# Altium schematic sheet 04_VGA.SchDoc(04_VGA)
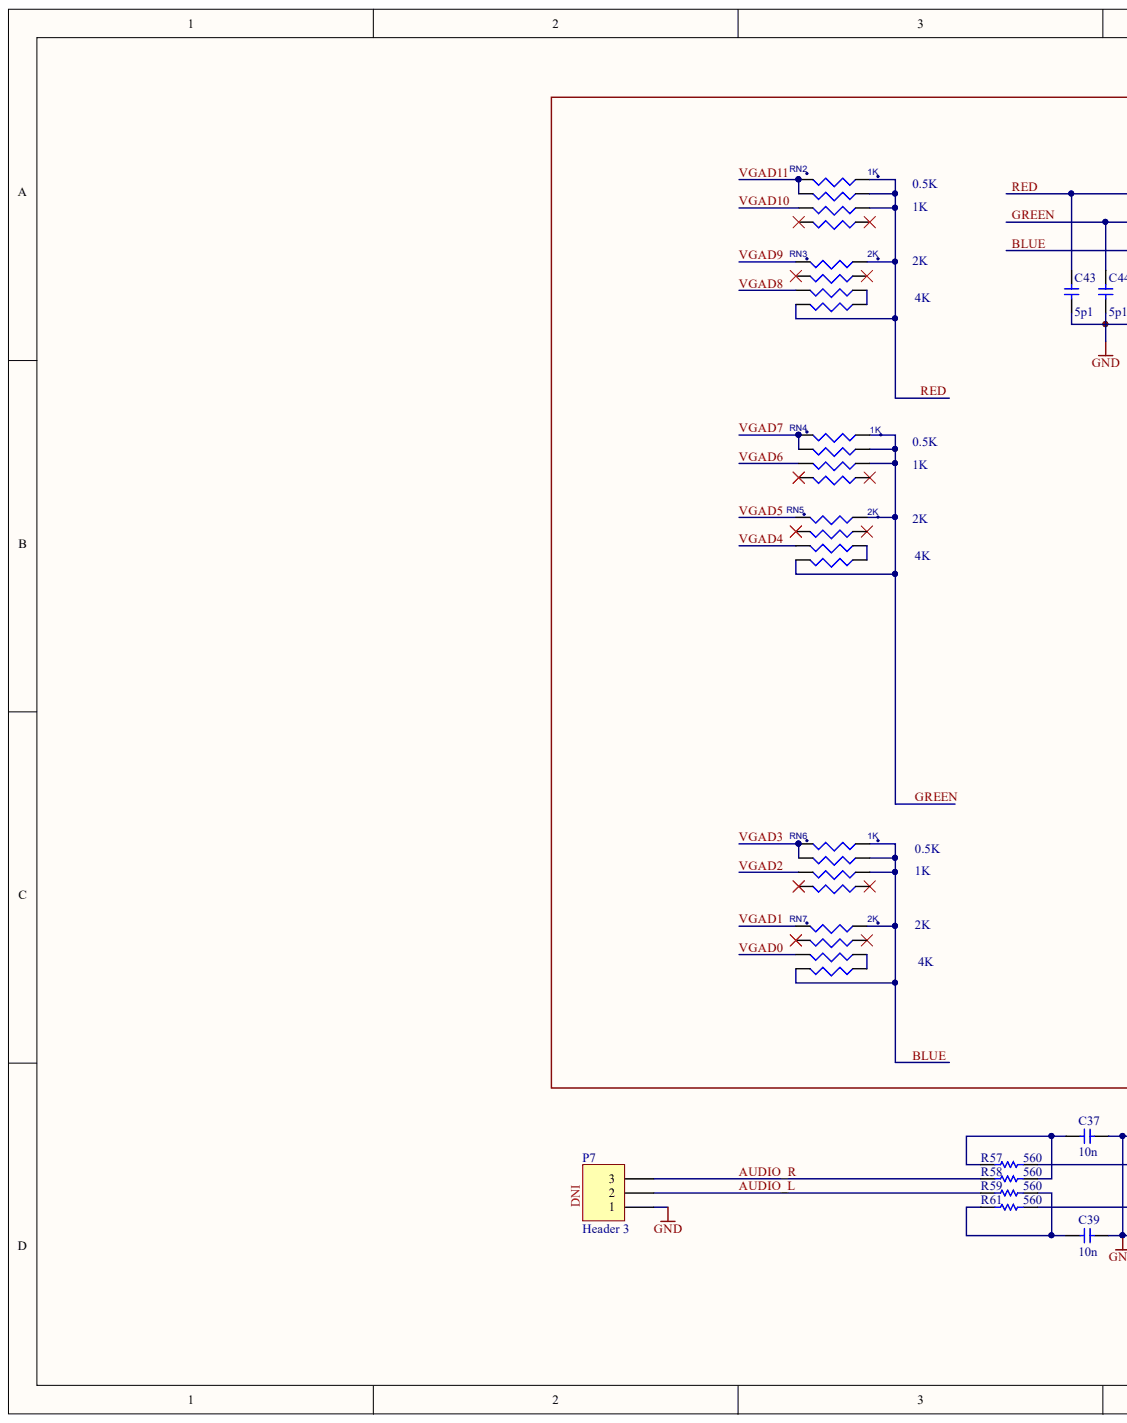
[diagram p0: 04_VGA.SchDoc, left]
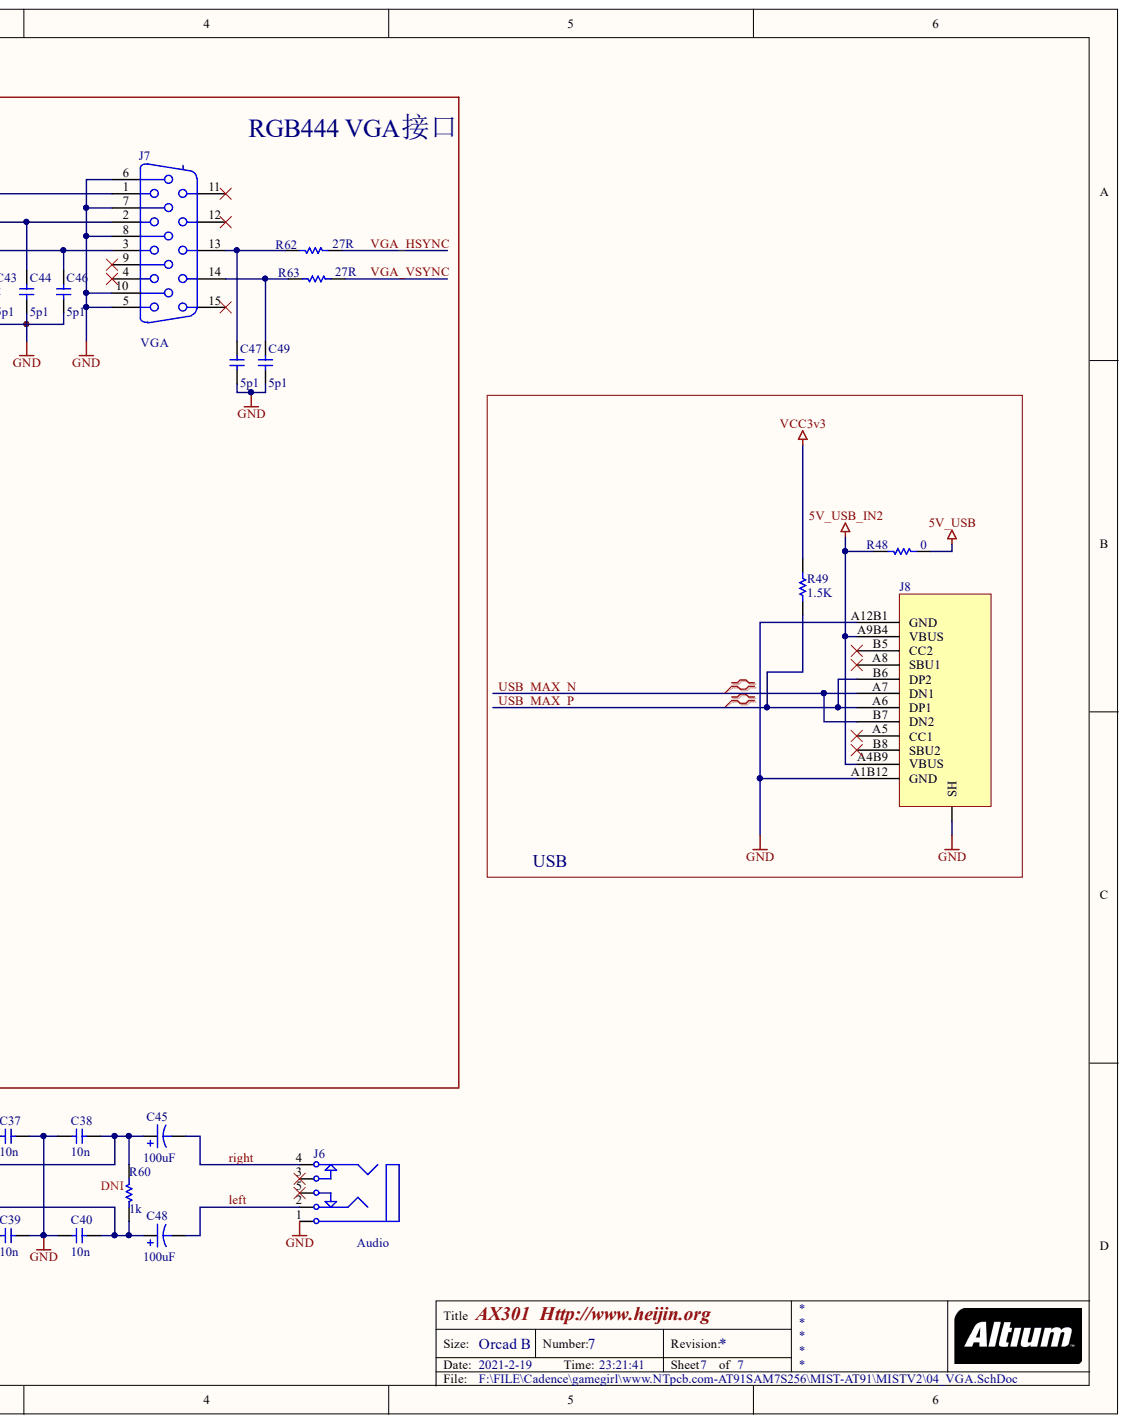
[diagram p1: 04_VGA.SchDoc, right]

## Parts
C37  value 10n  [0603]  (hidden comment: 0603)
C38  value 10n  [0603]  (hidden comment: 0603)
C39  value 10n  [0603]  (hidden comment: 0603)
C40  value 10n  [0603]  (hidden comment: 0603)
C43  value 5p1  [0603]  (hidden comment: 0603)
C44  value 5p1  [0603]  (hidden comment: 0603)
C45  value 100uF  [CAPT]  (hidden comment: T520D)
C46  value 5p1  [0603]  (hidden comment: 0603)
C47  value 5p1  [0603]  (hidden comment: 0603)
C48  value 100uF  [CAPT]  (hidden comment: T520D)
C49  value 5p1  [0603]  (hidden comment: 0603)
J6  Audio  [phonejack]
J7  VGA  [VGA]
J8  Type_C_16P  [Type_C_16P]  (value not drawn)
P7  Header 3  [HDR1X3]
R48  value 0  [0603]  (hidden comment: 0603)
R49  value 1.5K  [0603]  (hidden comment: R0603)
R57  value 560  [0603]  (hidden comment: 0805)
R58  value 560  [0603]  (hidden comment: 0805)
R59  value 560  [0603]  (hidden comment: 0805)
R60  value 1k  [0603]  (hidden comment: 0603)
R61  value 560  [0603]  (hidden comment: 0805)
R62  value 27R  [0603]  (hidden comment: 0603)
R63  value 27R  [0603]  (hidden comment: 0603)
RN2  1K  [RN-8P]
RN3  2K  [RN-8P]
RN4  1K  [RN-8P]
RN5  2K  [RN-8P]
RN6  1K  [RN-8P]
RN7  2K  [RN-8P]

## Nets
5V_USB: R48.1
5V_USB_IN2: J8.A4B9(VBUS) J8.A9B4(VBUS) R48.2
GND: C37.1 C38.2 C39.1 C40.2 C43.2 C44.2 C46.2 C47.2 C49.2 J6.1 J7.5 J7.6 J7.7 J7.8 J7.10 J8.0(SH) J8.A1B12(GND) J8.A12B1(GND) P7.1
VCC3V3: R49.1
AUDIO_L: P7.2 R59.1
AUDIO_R: P7.3 R58.1
BLUE: C46.1 J7.3 RN6.2 RN6.3 RN6.4 RN7.4 RN7.8
GREEN: C44.1 J7.2 RN4.2 RN4.3 RN4.4 RN5.4 RN5.8
LEFT: C48.2 J6.2
RED: C43.1 J7.1 RN2.2 RN2.3 RN2.4 RN3.4 RN3.8
RIGHT: C45.2 J6.4
USB_MAX_N: J8.A7(DN1) J8.B7(DN2)
USB_MAX_P: J8.A6(DP1) J8.B6(DP2) R49.2
VGA_HSYNC: R62.1
VGA_VSYNC: R63.1
VGAD0: RN7.7
VGAD1: RN7.5
VGAD2: RN6.7
VGAD3: RN6.5 RN6.6
VGAD4: RN5.7
VGAD5: RN5.5
VGAD6: RN4.7
VGAD7: RN4.5 RN4.6
VGAD8: RN3.7
VGAD9: RN3.5
VGAD10: RN2.7
VGAD11: RN2.5 RN2.6
NetC37_2: C37.2 R57.1 R58.2
NetC38_1: C38.1 C45.1 R57.2 R60.1
NetC39_2: C39.2 R59.2 R61.1
NetC40_1: C40.1 C48.1 R60.2 R61.2
NetC47_1: C47.1 J7.13 R62.2
NetC49_1: C49.1 J7.14 R63.2
NetRN3_1: RN3.1 RN3.2
NetRN5_1: RN5.1 RN5.2
NetRN7_1: RN7.1 RN7.2

Not connected: J6 3 5; J7 4 9 11 12 15; J8 A5(CC1) A8(SBU1) B5(CC2) B8(SBU2); RN2 1 8; RN3 3 6; RN4 1 8; RN5 3 6; RN6 1 8; RN7 3 6

## Library data of the parts
C37-C40 = CAP_0603: 0603 footprint non-polorised capacitor; Component Kind: Standard; Library Name: Integrated Comp_lib.IntLib
C43 C44 C46 C47 C49 = CAP_0805: 0805 footprint non-polarised capacitor; Component Kind: Standard; Library Name: Integrated Comp_lib.IntLib
C45 C48 = T520D: Polymer Tantalum Chip Capacitor, T520 Series - KO-CAP Polymer; Code_JEITA: 7343-31; Component Kind: Standard; ComponentLink1Description: Manufacturer Link; ComponentLink1URL: http://www.kemet.com/; ComponentLink2Description: Datasheet; ComponentLink2URL: http://www.kemet.com/kemet/web/homepage/kechome.nsf/vapubfilesname/F3102TA.pdf/$file/F3102TA.pdf; DatasheetDocument: Mar-2001; LatestRevisionDate: 19-Mar-2004; LatestRevisionNote: Silkscreen dimensions re-sized.; Library Name: lib.PcbLib; PackageDocument: Mar-2001; PackageReference: D; Published: 17-Jul-2002; Signal Integrity: T520D; Simulation: CAP; Publisher: Altium Limited
J6 = phonejack: Component Kind: Standard; Library Name: lib.SchLib
J7 = Connector 15: Receptacle Assembly, 15-Pin, Sim Line Connector; Gender: Receptacle Header; PackageDocument: B; Positions: 15; Component Kind: Standard; DatasheetDocument: 24-Nov-1999; LatestRevisionDate: 17-Jul-2002; LatestRevisionNote: Re-released for DXP Platform.; Library Name: Miscellaneous Connectors.IntLib; Orientation: Right Angle; PackageReference: 788574; Published: 15-Jun-2001; Publisher: Altium Limited
P7 = Header 3: Header, 3-Pin; Component Kind: Standard; LatestRevisionDate: 17-Jul-2002; LatestRevisionNote: Re-released for DXP Platform.; Library Name: Miscellaneous Connectors.IntLib; Signal Integrity: Connector; Publisher: Altium Limited
R48 R60 R62 R63 = RES_0603: 0603 footprint resistor; Component Kind: Standard; Library Name: Integrated Comp_lib.IntLib
R49 = R0603: Resistor; STUFF: NO; Component Kind: Standard; LatestRevisionDate: 17-Jul-2002; LatestRevisionNote: Re-released for DXP Platform.; Library Name: MYLIB.IntLib; PackageDescription: Axial Device, Thru-Hole; 2 Leads; 0.3 in Pin Spacing; PackageReference: AXIAL-0.3; Published: 8-Jun-2000; Signal Integrity: Res; Simulation: RESISTOR; Publisher: Altium Limited
R57-R59 R61 = RES_0805: 0805 footprint resistor; Component Kind: Standard; Library Name: Integrated Comp_lib.IntLib
RN2-RN7 = RN_Dup1: Component Kind: Standard
J8 = Type_C_16P: Component Kind: Standard; Library Name: ICESugar.SCHLIB
